# Altium schematic sheet [04] - Keyboard Matrix 2.SchDoc("[04] - Keyboard Matrix 2")
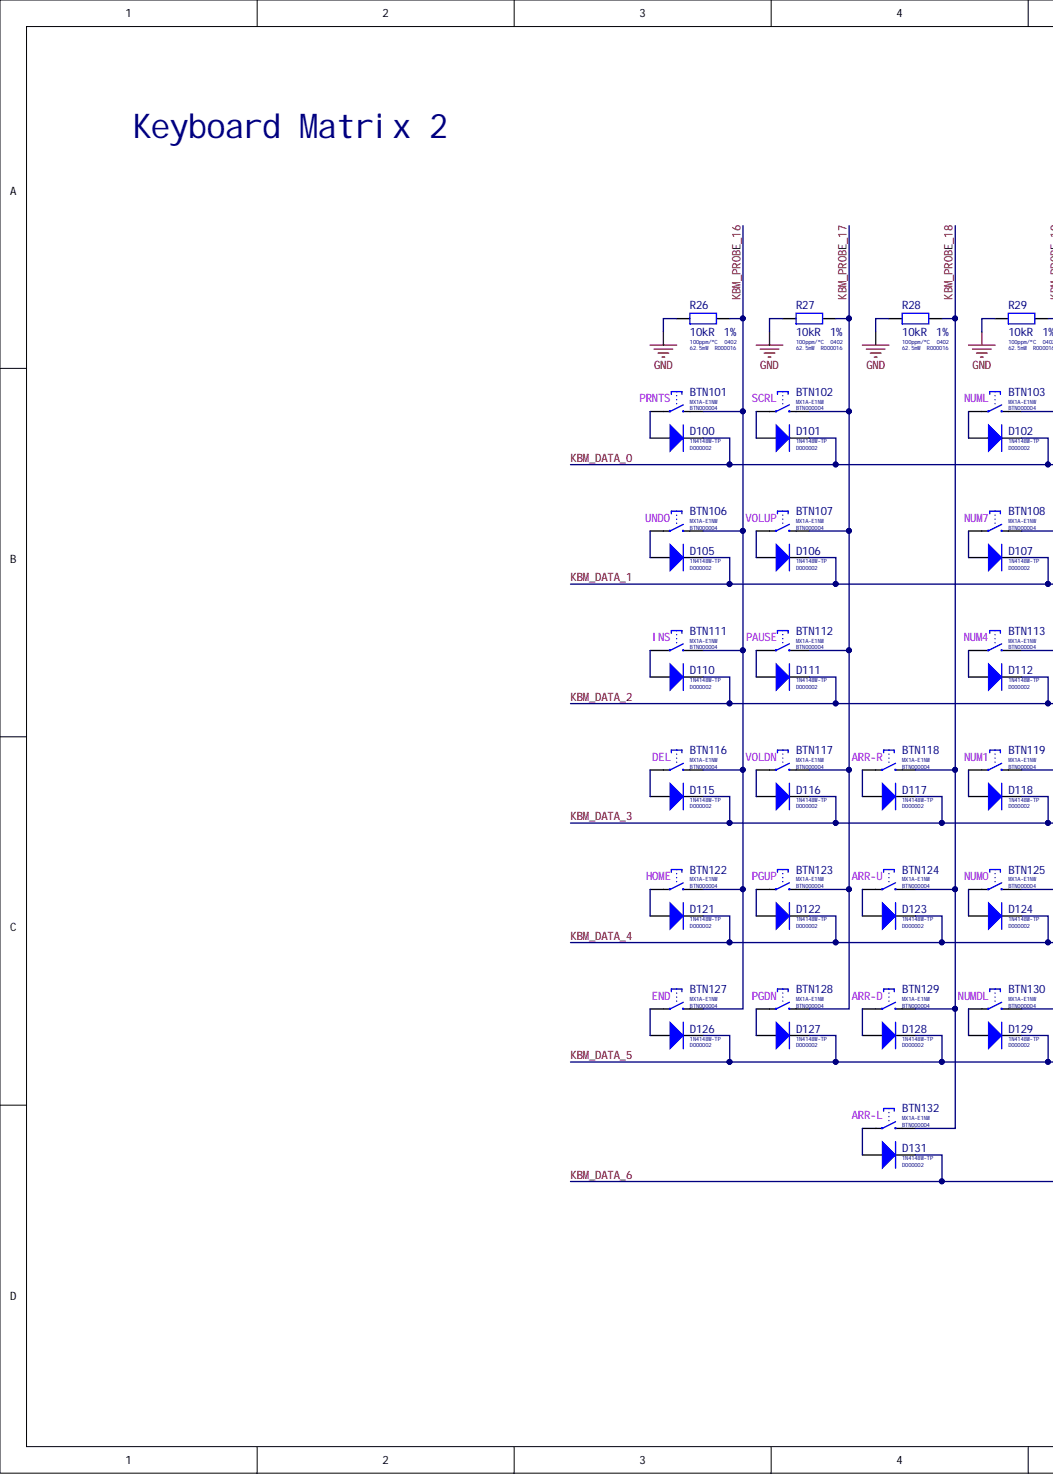
[diagram p0: [04] - Keyboard Matrix 2.SchDoc, left]
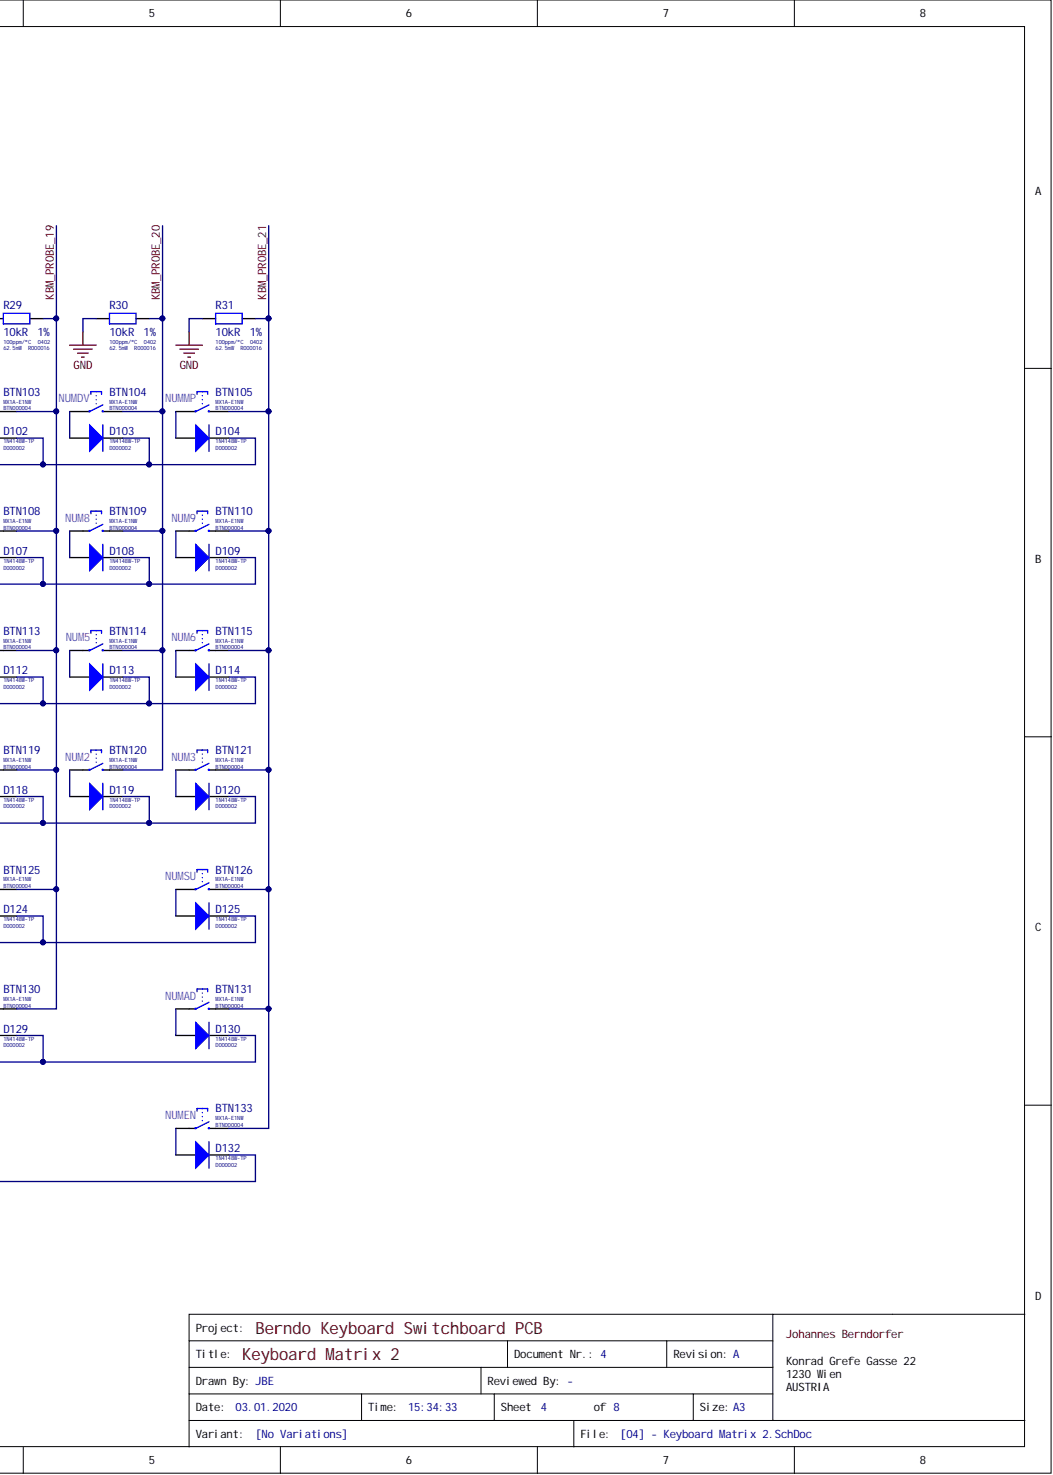
[diagram p1: [04] - Keyboard Matrix 2.SchDoc, right]

## Parts
BTN101  MX1A-E1NW  Key Function: PRNTS  Internal Part Number: BTN000004  [BTN-THT-MX-CHERRY]
BTN102  MX1A-E1NW  Key Function: SCRL  Internal Part Number: BTN000004  [BTN-THT-MX-CHERRY]
BTN103  MX1A-E1NW  Key Function: NUML  Internal Part Number: BTN000004  [BTN-THT-MX-CHERRY]
BTN104  MX1A-E1NW  Key Function: NUMDV  Internal Part Number: BTN000004  [BTN-THT-MX-CHERRY]
BTN105  MX1A-E1NW  Key Function: NUMMP  Internal Part Number: BTN000004  [BTN-THT-MX-CHERRY]
BTN106  MX1A-E1NW  Key Function: UNDO  Internal Part Number: BTN000004  [BTN-THT-MX-CHERRY]
BTN107  MX1A-E1NW  Key Function: VOLUP  Internal Part Number: BTN000004  [BTN-THT-MX-CHERRY]
BTN108  MX1A-E1NW  Key Function: NUM7  Internal Part Number: BTN000004  [BTN-THT-MX-CHERRY]
BTN109  MX1A-E1NW  Key Function: NUM8  Internal Part Number: BTN000004  [BTN-THT-MX-CHERRY]
BTN110  MX1A-E1NW  Key Function: NUM9  Internal Part Number: BTN000004  [BTN-THT-MX-CHERRY]
BTN111  MX1A-E1NW  Key Function: INS  Internal Part Number: BTN000004  [BTN-THT-MX-CHERRY]
BTN112  MX1A-E1NW  Key Function: PAUSE  Internal Part Number: BTN000004  [BTN-THT-MX-CHERRY]
BTN113  MX1A-E1NW  Key Function: NUM4  Internal Part Number: BTN000004  [BTN-THT-MX-CHERRY]
BTN114  MX1A-E1NW  Key Function: NUM5  Internal Part Number: BTN000004  [BTN-THT-MX-CHERRY]
BTN115  MX1A-E1NW  Key Function: NUM6  Internal Part Number: BTN000004  [BTN-THT-MX-CHERRY]
BTN116  MX1A-E1NW  Key Function: DEL  Internal Part Number: BTN000004  [BTN-THT-MX-CHERRY]
BTN117  MX1A-E1NW  Key Function: VOLDN  Internal Part Number: BTN000004  [BTN-THT-MX-CHERRY]
BTN118  MX1A-E1NW  Key Function: ARR-R  Internal Part Number: BTN000004  [BTN-THT-MX-CHERRY]
BTN119  MX1A-E1NW  Key Function: NUM1  Internal Part Number: BTN000004  [BTN-THT-MX-CHERRY]
BTN120  MX1A-E1NW  Key Function: NUM2  Internal Part Number: BTN000004  [BTN-THT-MX-CHERRY]
BTN121  MX1A-E1NW  Key Function: NUM3  Internal Part Number: BTN000004  [BTN-THT-MX-CHERRY]
BTN122  MX1A-E1NW  Key Function: HOME  Internal Part Number: BTN000004  [BTN-THT-MX-CHERRY]
BTN123  MX1A-E1NW  Key Function: PGUP  Internal Part Number: BTN000004  [BTN-THT-MX-CHERRY]
BTN124  MX1A-E1NW  Key Function: ARR-U  Internal Part Number: BTN000004  [BTN-THT-MX-CHERRY]
BTN125  MX1A-E1NW  Key Function: NUM0  Internal Part Number: BTN000004  [BTN-THT-MX-CHERRY]
BTN126  MX1A-E1NW  Key Function: NUMSU  Internal Part Number: BTN000004  [BTN-THT-MX-CHERRY]
BTN127  MX1A-E1NW  Key Function: END  Internal Part Number: BTN000004  [BTN-THT-MX-CHERRY]
BTN128  MX1A-E1NW  Key Function: PGDN  Internal Part Number: BTN000004  [BTN-THT-MX-CHERRY]
BTN129  MX1A-E1NW  Key Function: ARR-D  Internal Part Number: BTN000004  [BTN-THT-MX-CHERRY]
BTN130  MX1A-E1NW  Key Function: NUMDL  Internal Part Number: BTN000004  [BTN-THT-MX-CHERRY]
BTN131  MX1A-E1NW  Key Function: NUMAD  Internal Part Number: BTN000004  [BTN-THT-MX-CHERRY]
BTN132  MX1A-E1NW  Key Function: ARR-L  Internal Part Number: BTN000004  [BTN-THT-MX-CHERRY]
BTN133  MX1A-E1NW  Key Function: NUMEN  Internal Part Number: BTN000004  [BTN-THT-MX-CHERRY]
D100  1N4148W-TP  Internal Part Number: D000002  [D-SMD-SOD-123-MICRO-COMMERCIAL-COMPONENTS]
D101  1N4148W-TP  Internal Part Number: D000002  [D-SMD-SOD-123-MICRO-COMMERCIAL-COMPONENTS]
D102  1N4148W-TP  Internal Part Number: D000002  [D-SMD-SOD-123-MICRO-COMMERCIAL-COMPONENTS]
D103  1N4148W-TP  Internal Part Number: D000002  [D-SMD-SOD-123-MICRO-COMMERCIAL-COMPONENTS]
D104  1N4148W-TP  Internal Part Number: D000002  [D-SMD-SOD-123-MICRO-COMMERCIAL-COMPONENTS]
D105  1N4148W-TP  Internal Part Number: D000002  [D-SMD-SOD-123-MICRO-COMMERCIAL-COMPONENTS]
D106  1N4148W-TP  Internal Part Number: D000002  [D-SMD-SOD-123-MICRO-COMMERCIAL-COMPONENTS]
D107  1N4148W-TP  Internal Part Number: D000002  [D-SMD-SOD-123-MICRO-COMMERCIAL-COMPONENTS]
D108  1N4148W-TP  Internal Part Number: D000002  [D-SMD-SOD-123-MICRO-COMMERCIAL-COMPONENTS]
D109  1N4148W-TP  Internal Part Number: D000002  [D-SMD-SOD-123-MICRO-COMMERCIAL-COMPONENTS]
D110  1N4148W-TP  Internal Part Number: D000002  [D-SMD-SOD-123-MICRO-COMMERCIAL-COMPONENTS]
D111  1N4148W-TP  Internal Part Number: D000002  [D-SMD-SOD-123-MICRO-COMMERCIAL-COMPONENTS]
D112  1N4148W-TP  Internal Part Number: D000002  [D-SMD-SOD-123-MICRO-COMMERCIAL-COMPONENTS]
D113  1N4148W-TP  Internal Part Number: D000002  [D-SMD-SOD-123-MICRO-COMMERCIAL-COMPONENTS]
D114  1N4148W-TP  Internal Part Number: D000002  [D-SMD-SOD-123-MICRO-COMMERCIAL-COMPONENTS]
D115  1N4148W-TP  Internal Part Number: D000002  [D-SMD-SOD-123-MICRO-COMMERCIAL-COMPONENTS]
D116  1N4148W-TP  Internal Part Number: D000002  [D-SMD-SOD-123-MICRO-COMMERCIAL-COMPONENTS]
D117  1N4148W-TP  Internal Part Number: D000002  [D-SMD-SOD-123-MICRO-COMMERCIAL-COMPONENTS]
D118  1N4148W-TP  Internal Part Number: D000002  [D-SMD-SOD-123-MICRO-COMMERCIAL-COMPONENTS]
D119  1N4148W-TP  Internal Part Number: D000002  [D-SMD-SOD-123-MICRO-COMMERCIAL-COMPONENTS]
D120  1N4148W-TP  Internal Part Number: D000002  [D-SMD-SOD-123-MICRO-COMMERCIAL-COMPONENTS]
D121  1N4148W-TP  Internal Part Number: D000002  [D-SMD-SOD-123-MICRO-COMMERCIAL-COMPONENTS]
D122  1N4148W-TP  Internal Part Number: D000002  [D-SMD-SOD-123-MICRO-COMMERCIAL-COMPONENTS]
D123  1N4148W-TP  Internal Part Number: D000002  [D-SMD-SOD-123-MICRO-COMMERCIAL-COMPONENTS]
D124  1N4148W-TP  Internal Part Number: D000002  [D-SMD-SOD-123-MICRO-COMMERCIAL-COMPONENTS]
D125  1N4148W-TP  Internal Part Number: D000002  [D-SMD-SOD-123-MICRO-COMMERCIAL-COMPONENTS]
D126  1N4148W-TP  Internal Part Number: D000002  [D-SMD-SOD-123-MICRO-COMMERCIAL-COMPONENTS]
D127  1N4148W-TP  Internal Part Number: D000002  [D-SMD-SOD-123-MICRO-COMMERCIAL-COMPONENTS]
D128  1N4148W-TP  Internal Part Number: D000002  [D-SMD-SOD-123-MICRO-COMMERCIAL-COMPONENTS]
D129  1N4148W-TP  Internal Part Number: D000002  [D-SMD-SOD-123-MICRO-COMMERCIAL-COMPONENTS]
D130  1N4148W-TP  Internal Part Number: D000002  [D-SMD-SOD-123-MICRO-COMMERCIAL-COMPONENTS]
D131  1N4148W-TP  Internal Part Number: D000002  [D-SMD-SOD-123-MICRO-COMMERCIAL-COMPONENTS]
D132  1N4148W-TP  Internal Part Number: D000002  [D-SMD-SOD-123-MICRO-COMMERCIAL-COMPONENTS]
R26  10kR 1%  Tolerance: 1%  Temperature Coefficient: 100ppm/°C  Resistance: 10kR  Power Rating: 62.5mW  Internal Part Number: R000016  Case Code (Imperial): 0402  [RES-SMD-0402-0.4MM-IPC-MED]
R27  10kR 1%  Tolerance: 1%  Temperature Coefficient: 100ppm/°C  Resistance: 10kR  Power Rating: 62.5mW  Internal Part Number: R000016  Case Code (Imperial): 0402  [RES-SMD-0402-0.4MM-IPC-MED]
R28  10kR 1%  Tolerance: 1%  Temperature Coefficient: 100ppm/°C  Resistance: 10kR  Power Rating: 62.5mW  Internal Part Number: R000016  Case Code (Imperial): 0402  [RES-SMD-0402-0.4MM-IPC-MED]
R29  10kR 1%  Tolerance: 1%  Temperature Coefficient: 100ppm/°C  Resistance: 10kR  Power Rating: 62.5mW  Internal Part Number: R000016  Case Code (Imperial): 0402  [RES-SMD-0402-0.4MM-IPC-MED]
R30  10kR 1%  Tolerance: 1%  Temperature Coefficient: 100ppm/°C  Resistance: 10kR  Power Rating: 62.5mW  Internal Part Number: R000016  Case Code (Imperial): 0402  [RES-SMD-0402-0.4MM-IPC-MED]
R31  10kR 1%  Tolerance: 1%  Temperature Coefficient: 100ppm/°C  Resistance: 10kR  Power Rating: 62.5mW  Internal Part Number: R000016  Case Code (Imperial): 0402  [RES-SMD-0402-0.4MM-IPC-MED]

## Nets
GND: R26.1 R27.1 R28.1 R29.1 R30.1 R31.1
KBM_DATA_0: D100.1 D101.1 D102.1 D103.1 D104.1
KBM_DATA_1: D105.1 D106.1 D107.1 D108.1 D109.1
KBM_DATA_2: D110.1 D111.1 D112.1 D113.1 D114.1
KBM_DATA_3: D115.1 D116.1 D117.1 D118.1 D119.1 D120.1
KBM_DATA_4: D121.1 D122.1 D123.1 D124.1 D125.1
KBM_DATA_5: D126.1 D127.1 D128.1 D129.1 D130.1
KBM_DATA_6: D131.1 D132.1
KBM_PROBE_16: BTN101.2 BTN106.2 BTN111.2 BTN116.2 BTN122.2 BTN127.2 R26.2
KBM_PROBE_17: BTN102.2 BTN107.2 BTN112.2 BTN117.2 BTN123.2 BTN128.2 R27.2
KBM_PROBE_18: BTN118.2 BTN124.2 BTN129.2 BTN132.2 R28.2
KBM_PROBE_19: BTN103.2 BTN108.2 BTN113.2 BTN119.2 BTN125.2 BTN130.2 R29.2
KBM_PROBE_20: BTN104.2 BTN109.2 BTN114.2 BTN120.2 R30.2
KBM_PROBE_21: BTN105.2 BTN110.2 BTN115.2 BTN121.2 BTN126.2 BTN131.2 BTN133.2 R31.2
NetBTN101_1: BTN101.1 D100.2
NetBTN102_1: BTN102.1 D101.2
NetBTN103_1: BTN103.1 D102.2
NetBTN104_1: BTN104.1 D103.2
NetBTN105_1: BTN105.1 D104.2
NetBTN106_1: BTN106.1 D105.2
NetBTN107_1: BTN107.1 D106.2
NetBTN108_1: BTN108.1 D107.2
NetBTN109_1: BTN109.1 D108.2
NetBTN110_1: BTN110.1 D109.2
NetBTN111_1: BTN111.1 D110.2
NetBTN112_1: BTN112.1 D111.2
NetBTN113_1: BTN113.1 D112.2
NetBTN114_1: BTN114.1 D113.2
NetBTN115_1: BTN115.1 D114.2
NetBTN116_1: BTN116.1 D115.2
NetBTN117_1: BTN117.1 D116.2
NetBTN118_1: BTN118.1 D117.2
NetBTN119_1: BTN119.1 D118.2
NetBTN120_1: BTN120.1 D119.2
NetBTN121_1: BTN121.1 D120.2
NetBTN122_1: BTN122.1 D121.2
NetBTN123_1: BTN123.1 D122.2
NetBTN124_1: BTN124.1 D123.2
NetBTN125_1: BTN125.1 D124.2
NetBTN126_1: BTN126.1 D125.2
NetBTN127_1: BTN127.1 D126.2
NetBTN128_1: BTN128.1 D127.2
NetBTN129_1: BTN129.1 D128.2
NetBTN130_1: BTN130.1 D129.2
NetBTN131_1: BTN131.1 D130.2
NetBTN132_1: BTN132.1 D131.2
NetBTN133_1: BTN133.1 D132.2

## Library data of the parts
BTN101-BTN133 = MX1A-E1NW: BTN CHERRY MX BLUE SPST-NO 12V 10mA; Throw Configuration: SPST; Switch Function: Off-Mom; Row Spacing: 2.54mm; Operating Force: 0.5N; Mechanical Life: 20000000Cycles; Contact Resistance: 200mR; Actuator Length: 3.6mm; Width: 15.6mm; Voltage Rating (DC): 12V; Voltage Rating (AC): 12V; Termination: PC Pin; Terminal Pitch: 6.35mm; Supplier Part Number 1: CH197-ND; Supplier 1: Digi-Key; Stock 1: 3965; RoHS: Yes; Pricing 1: 1 = 0,90000, 10 = 0,84000, 25 = 0,80000, 50 = 0,76000, 100 = 0,74000, 250 = 0,72000, 500 = 0,68000, 1000 = 0,64000, 5000 = 0,54000 (USD); Packaging: Bulk; Package Quantity: 1000; Orientation: Straight; Number of Terminals: 2; Mount: Through Hole; Min Operating Temperature: -10°C; Max Voltage Rating (DC): 12V; Max Voltage Rating (AC): 12V; Max Operating Temperature: 70°C; Max Current Rating: 10mA; Manufacturer Part Number: MX1A-E1NW; Manufacturer: Cherry; Library Name: Altium_Lib_Standard.IntLib; Length: 15.6mm; Lead Free: Lead Free; Housing Material: Thermoplastic; Flammability Rating: UL94 HB; Electrical Life: 20000000Cycles; Current Rating: 10mA; Contact Plating: Gold; Contact Material: Gold,Silver; Contact Current Rating: 10mA; ComponentLink2URL: https://datasheet.ciiva.com/5259/mx-cat-216303-5259204.pdf?src-supplier=Mouser; ComponentLink2Description: https://datasheet.ciiva.com/5259/mx-cat-216303-5259204.pdf?src-supplier=Mouser; ComponentLink1URL: https://datasheet.ciiva.com/2869/mx20series-2869568.pdf?src-supplier=Digi-Key; ComponentLink1Description: https://datasheet.ciiva.com/2869/mx20series-2869568.pdf?src-supplier=Digi-Key; Component Kind: Standard
D100-D132 = 1N4148W-TP: DIODE GEN-PURP 100V 150mA 1V25@150mA SMD SOD-23; Manufacturer: MCC; Reverse Recovery Time: 4ns; Power Dissipation: 400mW; Polarity: Standard; Peak Non-Repetitive Surge Current: 2A; Max Reverse Leakage Current: 5uA; Max Forward Surge Current (Ifsm): 1A; Forward Voltage: 1V; Element Configuration: Single; Capacitance: 4pF; Width: 1.8mm; Voltage Rating (DC): 100V; Supplier Part Number 1: 1N4148WTPMSCT-ND; Supplier 1: Digi-Key; Stock 1: 1077433; RoHS: Yes; Recovery Time: 4ns; REACH SVHC: Unknown; Radiation Hardening: No; Pricing 1: 1 = 0,13000, 10 = 0,11900, 100 = 0,06480, 500 = 0,03986, 1000 = 0,02718 (USD); Packaging: Tape and Reel; Package Quantity: 3000; Output Current: 150mA; Number of Pins: 2; Mount: Surface Mount; Min Operating Temperature: -65°C; Max Surge Current: 2A; Max Reverse Voltage (DC): 100V; Max Repetitive Reverse Voltage (Vrrm): 100V; Max Operating Temperature: 150°C; Manufacturer Part Number: 1N4148W-TP; Library Name: Altium_Lib_Standard.IntLib; Length: 2.85mm; Lead Free: Lead Free; Height: 1.2mm; Forward Current: 150mA; Current Rating: 150mA; ComponentLink9URL: https://datasheet.ciiva.com/22747/1684829-22747439.pdf?src-supplier=Element14; ComponentLink9Description: https://datasheet.ciiva.com/22747/1684829-22747439.pdf?src-supplier=Element14; ComponentLink8URL: https://datasheet.ciiva.com/911/1n4148wsod123-911678.pdf?src-supplier=Digi-Key; ComponentLink8Description: https://datasheet.ciiva.com/911/1n4148wsod123-911678.pdf?src-supplier=Digi-Key; ComponentLink7URL: https://datasheet.ciiva.com/911/1n4148wsod123-911677.pdf?src-supplier=Digi-Key; ComponentLink7Description: https://datasheet.ciiva.com/911/1n4148wsod123-911677.pdf?src-supplier=Digi-Key; ComponentLink6URL: https://datasheet.ciiva.com/7622/1684829-7622556.pdf?src-supplier=Element14; ComponentLink6Description: https://datasheet.ciiva.com/7622/1684829-7622556.pdf?src-supplier=Element14; ComponentLink5URL: https://datasheet.ciiva.com/911/1n4148wsod123-911676.pdf?src-supplier=Digi-Key; ComponentLink5Description: https://datasheet.ciiva.com/911/1n4148wsod123-911676.pdf?src-supplier=Digi-Key; ComponentLink4URL: https://datasheet.ciiva.com/14557/70055519-14557767.pdf?src-supplier=Allied+Electronics; ComponentLink4Description: https://datasheet.ciiva.com/14557/70055519-14557767.pdf?src-supplier=Allied+Electronics; ComponentLink3URL: https://datasheet.ciiva.com/4435/1n4148wsod123-48460-4435223.pdf?src-supplier=Mouser; ComponentLink3Description: https://datasheet.ciiva.com/4435/1n4148wsod123-48460-4435223.pdf?src-supplier=Mouser; ComponentLink2URL: https://datasheet.ciiva.com/16836/1n4148wsod123-16836277.pdf?src-supplier=Future+Electronics; ComponentLink2Description: https://datasheet.ciiva.com/16836/1n4148wsod123-16836277.pdf?src-supplier=Future+Electronics; ComponentLink1URL: https://datasheet.ciiva.com/1243/1684829-1243908.pdf?src-supplier=Newark; ComponentLink1Description: https://datasheet.ciiva.com/1243/1684829-1243908.pdf?src-supplier=Newark; Component Kind: Standard; Average Rectified Current: 150mA
R26-R31 = RC0402FR-0710KL: RES 10K 1% 1/16W SMD 0402 YAEGO; Manufacturer: Yageo; Working Voltage: 50V; Width: 0.5mm; Voltage Rating (DC): 50V; Voltage Rating: 50V; Termination: SMD/SMT; Supplier Part Number 1: 311-10.0KLRCT-ND; Supplier 1: Digi-Key; Stock 1: 86154015; RoHS: Yes; REACH SVHC: No SVHC; Pricing 1: 1 = 0,10000, 10 = 0,01600, 100 = 0,00670, 1000 = 0,00301, 2500 = 0,00261, 5000 = 0,00215 (USD); Packaging: Tape and Reel; Package Quantity: 10000; Number of Terminations: 2; Number of Pins: 2; Min Operating Temperature: -55°C; Military Standard: Not; Max Operating Temperature: 155°C; Manufacturer Part Number: RC0402FR-0710KL; Library Name: Altium_Lib_Standard.IntLib; Length: 1mm; Lead Pitch: 0.2mm; Lead Free: Lead Free; Height: 0.32mm; Depth: 0.5mm; Composition: Thick Film; ComponentLink13URL: https://datasheet.ciiva.com/17070/pyu-rc0402-51-rohs-l-6-r-17070335.pdf?src-supplier=Future+Electronics; ComponentLink13Description: https://datasheet.ciiva.com/17070/pyu-rc0402-51-rohs-l-6-r-17070335.pdf?src-supplier=Future+Electronics; ComponentLink12URL: https://datasheet.ciiva.com/7849/1018594-7849967.pdf?src-supplier=Element14; ComponentLink12Description: https://datasheet.ciiva.com/7849/1018594-7849967.pdf?src-supplier=Element14; ComponentLink11URL: https://datasheet.ciiva.com/7849/1018594-7849966.pdf?src-supplier=Element14; ComponentLink11Description: https://datasheet.ciiva.com/7849/1018594-7849966.pdf?src-supplier=Element14; ComponentLink10URL: https://datasheet.ciiva.com/24070/pyu-rc0402-51-rohs-l-6-r-8721-24070077.pdf?src-supplier=Mouser; ComponentLink10Description: https://datasheet.ciiva.com/24070/pyu-rc0402-51-rohs-l-6-r-8721-24070077.pdf?src-supplier=Mouser; ComponentLink9URL: https://datasheet.ciiva.com/15189/getdatasheetpartid-329253-15189431.pdf?src-supplier=Verical; ComponentLink9Description: https://datasheet.ciiva.com/15189/getdatasheetpartid-329253-15189431.pdf?src-supplier=Verical; ComponentLink8URL: https://datasheet.ciiva.com/11819/0900766b80c66297-11819487.pdf?src-supplier=RSComponents; ComponentLink8Description: https://datasheet.ciiva.com/11819/0900766b80c66297-11819487.pdf?src-supplier=RSComponents; ComponentLink7URL: https://datasheet.ciiva.com/7983/1018594-7983486.pdf?src-supplier=Element14; ComponentLink7Description: https://datasheet.ciiva.com/7983/1018594-7983486.pdf?src-supplier=Element14; ComponentLink6URL: https://datasheet.ciiva.com/12667/pyu-rc0402-51-rohs-l-6-r-12667067.pdf?src-supplier=Mouser; ComponentLink6Description: https://datasheet.ciiva.com/12667/pyu-rc0402-51-rohs-l-6-r-12667067.pdf?src-supplier=Mouser; ComponentLink5URL: https://datasheet.ciiva.com/22747/1018594-22747512.pdf?src-supplier=Element14; ComponentLink5Description: https://datasheet.ciiva.com/22747/1018594-22747512.pdf?src-supplier=Element14; ComponentLink4URL: https://datasheet.ciiva.com/7849/1784430-7849815.pdf?src-supplier=Element14; ComponentLink4Description: https://datasheet.ciiva.com/7849/1784430-7849815.pdf?src-supplier=Element14; ComponentLink3URL: https://datasheet.ciiva.com/7849/1686128-7849814.pdf?src-supplier=Element14; ComponentLink3Description: https://datasheet.ciiva.com/7849/1686128-7849814.pdf?src-supplier=Element14; ComponentLink2URL: https://datasheet.ciiva.com/7849/1784430-7849813.pdf?src-supplier=Element14; ComponentLink2Description: https://datasheet.ciiva.com/7849/1784430-7849813.pdf?src-supplier=Element14; ComponentLink1URL: https://datasheet.ciiva.com/7660/38568-7660787.pdf?src-supplier=Element14; ComponentLink1Description: https://datasheet.ciiva.com/7660/38568-7660787.pdf?src-supplier=Element14; Component Kind: Standard; Case Code (Metric): 1005; Case/Package: 0402
